# Altium schematic sheet Transmitter.SchDoc
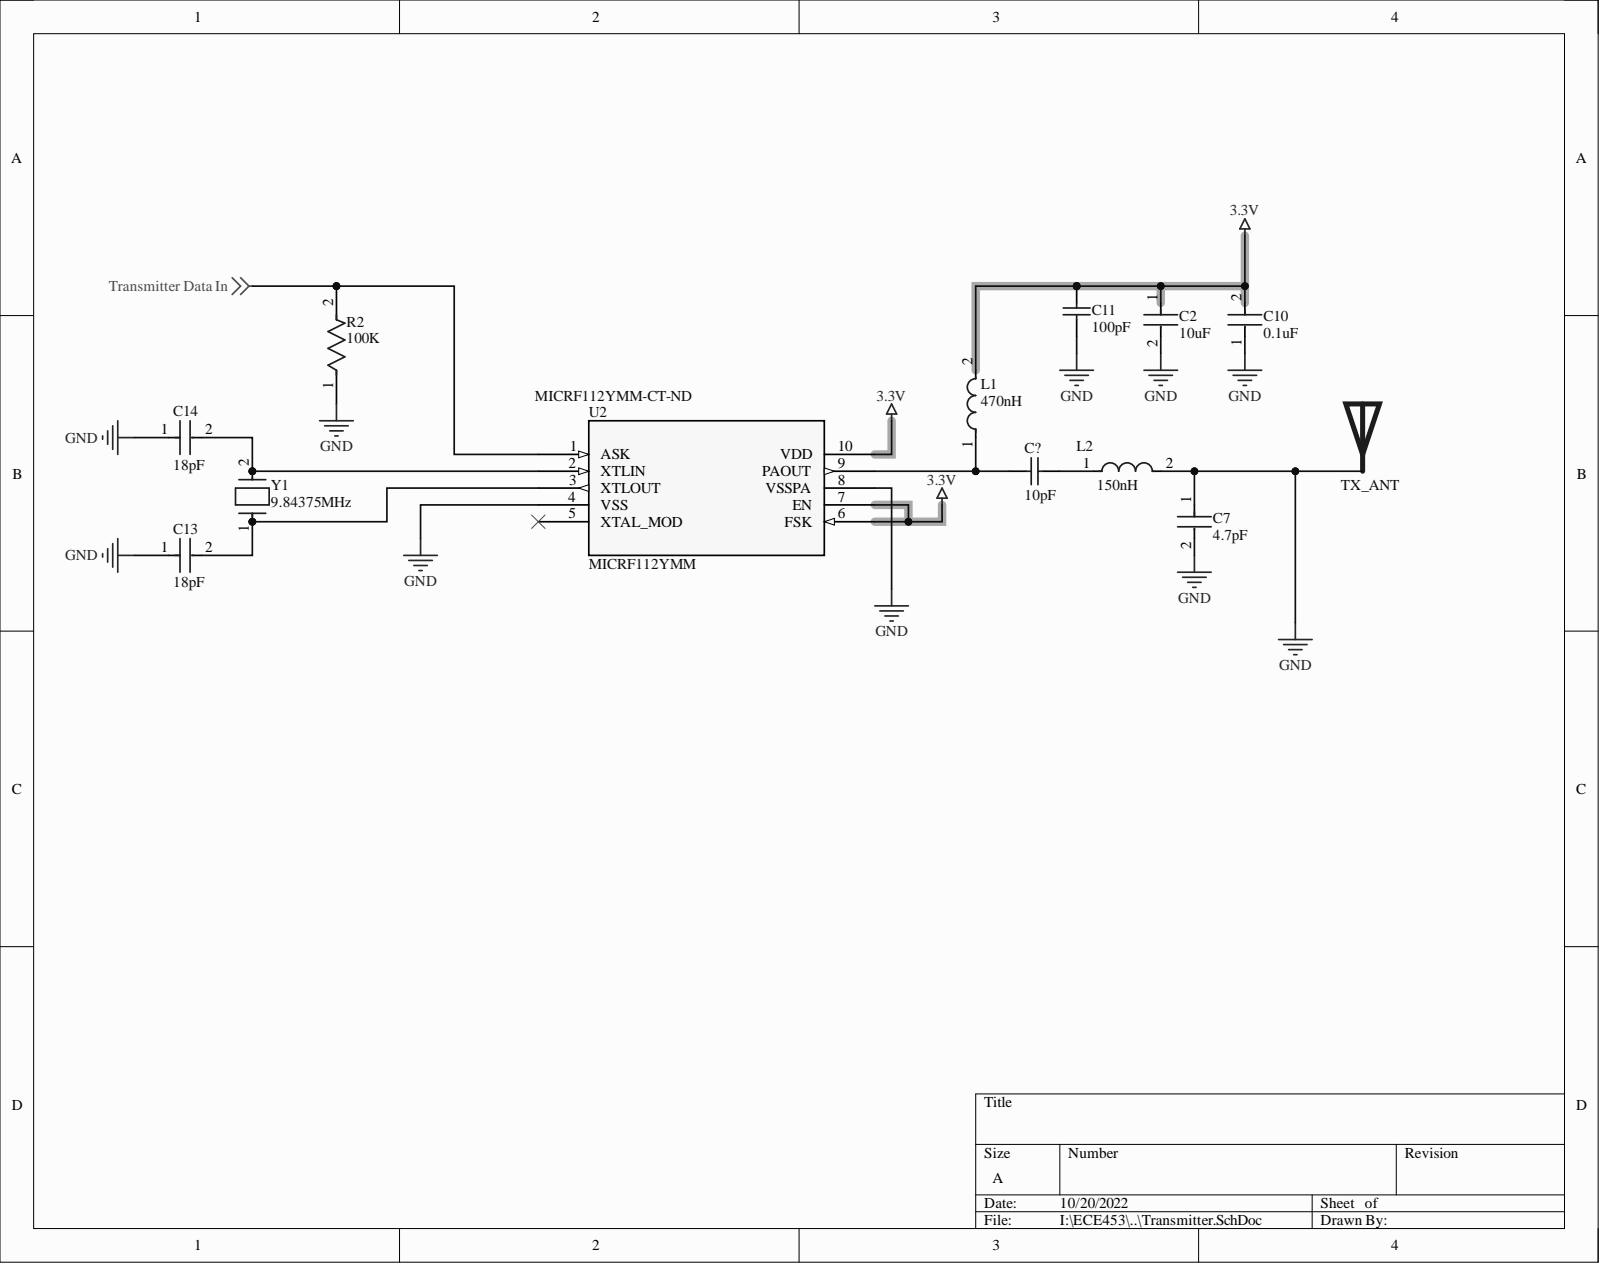
[diagram p0: Transmitter.SchDoc]

## Parts
C2  10uF  [FP-GRM188-0_1-e0_2_0_55-IPC_A]
C7  4.7pF  [FP-C0402-BB-MFG]
C10  0.1uF  [FP-GRM15-MFG]
C11  100pF  [CAPC1608X90X35NL10T15]
C13  18pF  [FP-C0805C-DN-MFG]
C14  18pF  [FP-C0805C-DN-MFG]
C?  10pF  [CAPC1608X90X35LL10T15]
L1  470nH  [FP-LQW2UAS_00-MFG]
L2  150nH  [FP-LQW2BHN_03-MFG]
R2  100K  [FP-ERJ2RK-IPC_C]
TX_ANT  TX Antenna  [TX Antenna]  (value not drawn)
U2  MICRF112YMM  Supplier Part Number 1: MICRF112YMM-CT-ND  [MSOP10_MC_MCH]
Y1  9.84375MHz  [FP-ABM7-MFG]

## Nets
3.3V: C2.1 C10.2 C11.2 L1.2 U2.6(FSK) U2.7(EN) U2.10(VDD)
GND: C2.2 C7.1 C7.2 C10.1 C11.1 C13.1 C14.1 L2.2 R2.1 U2.4(VSS) U2.8(VSSPA)
Transmitter Data In: R2.2 U2.1(ASK)
NetC13_2: C13.2 U2.3(XTLOUT) Y1.1
NetC14_2: C14.2 U2.2(XTLIN) Y1.2
NetC?_1: C?.1 L1.1 U2.9(PAOUT)
NetC?_2: C?.2 L2.1

Not connected: U2 5(XTAL_MOD)

## Library data of the parts
C2 = CMP-2006-02792-2: Chip Multilayer Ceramic Capacitors for General Purpose, 0603, 10uF, X5R, 15%, 20%, 6.3V; Capacitance: 10uF; Case Code (Imperial): 0603; Case Code (Metric): 1608; Case/Package: 0603; Component Kind: Standard; Depth: 0.8mm; Dielectric: X5R; Height: 0.8mm; Lead Free: Lead Free; Length: 1.6mm; Library Name: Altium Content Vault; Material: Ceramic; Max Operating Temperature: 85°C; Min Operating Temperature: -55°C; Mount: Surface Mount; Package Quantity: 4000; Packaging: Tape and Reel; Radiation Hardening: No; REACH SVHC: No SVHC; Termination: SMD/SMT; Thickness: 0.035inch; Tolerance: 20%; Voltage: 6.3V; Voltage Rating: 6.3V; Voltage Rating (DC): 6.3V; Width: 0.031inch
C7 = CMP-2008-03310-2: CAP CER 4.7PF 50V NP0 0402; Manufacturer: Kemet; Manufacturer Part Number: C0402C479C5GACTU; Material: Ceramic; Temperature Characteristic: C0G; Temperature Coefficient: 15%; Tolerance: 0.25 pF; Automotive: No; Capacitance: 4.7 pF; Category: Cap; Component Kind: Standard; ComponentLink2Description: Datasheet; ComponentLink2URL: https://content.kemet.com/datasheets/KEM_C1002_X7R_SMD.pdf; Device Class L1: Passive Components; Device Class L2: Capacitors; Device Class L3: Ceramic Capacitors; Digikey Description: CAP CER 4.7PF 50V NP0 0402; Height: 0.55mm; Ipc Land Pattern Name: CAPC10050X50; Lead Free: Yes; Library Name: Altium Content Vault; Mouser Description: Multilayer Ceramic Capacitors MLCC - SMD/SMT 50volts 4.7pF C0G .25pF; Octopart: https://octopart.com/c0402c479c5gactu-kemet-9144199; Package: C0402-BB; RoHS: TRUE; Temperature: -55°C to +125°C; Temperature Range High: +125°C; Temperature Range Low: -55°C; Value: 4.7 pF; Voltage Rating: 50 V
C10 = CMP-2100-03641-2: CAP CER 0.1UF 16V X7R 0402; Manufacturer: Murata; Manufacturer Part Number: GRM155R71C104KA88D; Capacitance: 0.10μF; Material: Ceramic; Standoff Height: 0mm; Tolerance: 10%; Value: 0.10μF; Voltage Rating: 16Vdc; Category: Cap; Component Kind: Standard; ComponentLink2Description: Datasheet; ComponentLink2URL: https://search.murata.co.jp/Ceramy/image/img/A01X/G101/ENG/GRM155R71H471KA01-01A.pdf; Device Class L1: Passive Components; Device Class L2: Capacitors; Device Class L3: Ceramic Capacitors; Digikey Description: CAP CER 0.1UF 16V X7R 0402; Height: 0.55mm; Ipc Land Pattern Name: CAPC10050X219; Lead Free: yes; Library Name: Altium Content Vault; Mouser Description: 0402 0.1 uF 16V ±10 % Tolerance X7R SMT Multilayer Ceramic Capacitor; Octopart: https://octopart.com/grm155r71c104ka88d-murata-196880; Package: GRM15; RoHS: TRUE; Temperature: -55°C to +125°C; Temperature Characteristic: X7R; Temperature Range High: +125°C; Temperature Range Low: -55°C
C11 = CMP-2006-02595-1: Manufacturer: Murata; Part Number: GRM1885C1H101JA01D; Component Kind: Standard; Library Name: Altium Content Vault
C13 C14 = CMP-2007-00302-2: CAP CER 18PF 50V C0G/NP0 0805; Manufacturer: KEMET; Manufacturer Part Number: C0805C180J5GACTU; Material: Ceramic; Temperature Characteristic: C0G; Temperature Coefficient: 30ppm/°C; Tolerance: 0.05; Automotive: No; Capacitance: 18 pF; Category: Cap; Component Kind: Standard; ComponentLink2Description: Datasheet; ComponentLink2URL: https://content.kemet.com/datasheets/KEM_C1003_C0G_SMD.pdf; Device Class L1: Passive Components; Device Class L2: Capacitors; Device Class L3: Ceramic Capacitors; Digikey Description: CAP CER 18PF 50V C0G/NP0 0805; Height: 0.88mm; Ipc Land Pattern Name: CAPC200125X78; Lead Free: Yes; Library Name: Altium Content Vault; Mouser Description: Cap Ceramic 18pF 50V C0G 5% SMD 0805 125C Paper T/R; Octopart: https://octopart.com/c0805c180j5gactu-kemet-144810; Package: C0805C-DN; RoHS: TRUE; Temperature: -55°C to +125°C; Temperature Range High: +125°C; Temperature Range Low: -55°C; Value: 18 pF; Voltage Rating: 50 V
C? = CMP-2006-02592-1: Manufacturer: Murata; Part Number: GRM1885C1H100JA01D; Component Kind: Standard; Library Name: Altium Content Vault
L1 = CMP-06042-015512-1: Wire Wound RF Inductor 470nH ±5% 470mA 1.19Ω 1008 (2520); Core Material: Air; DC Resistance (DCR): 1.19R; Q Factor: 45; Self Resonant Frequency: 450MHz; Shielding: Unshielded; Test Frequency: 25MHz; Case Code (Imperial): 1008; Case Code (Metric): 2520; Case/Package: 1008; Component Kind: Standard; Current Rating: 470mA; Depth: 2.65mm; Height: 1.83mm; Height - Seated (Max): 0.08inch; Inductance: 470nH; Inductance Tolerance: 5%; Lead Free: Lead Free; Length: 0.103inch; Library Name: Altium Content Vault; Max DC Current: 470mA; Max Operating Temperature: 125°C; Min Operating Temperature: -55°C; Mount: Surface Mount; Package Quantity: 2000; Packaging: Tape and Reel; Radiation Hardening: No; REACH SVHC: No SVHC; Resistor Type: Wirewound; Series: LQW2UA; Termination: SMD/SMT; Tolerance: 5%; Weight: 0.001376oz; Width: 0.096inch
L2 = CMP-06042-003065-1: Wire Wound RF Inductor 150nH ±5% 390mA 0.47Ω 0805 (2015); Core Material: Non-Magnetic; DC Resistance (DCR): 470mR; Q Factor: 30; Self Resonant Frequency: 350MHz; Shielding: Unshielded; Test Frequency: 100MHz; Case Code (Imperial): 0805; Case Code (Metric): 2012; Case/Package: 0805; Component Kind: Standard; Current Rating: 390mA; DC Current: 390mA; Depth: 1.7mm; Height: 1.7mm; Height - Seated (Max): 0.07inch; Inductance: 150nH; Lead Free: Lead Free; Length: 2mm; Library Name: Altium Content Vault; Max DC Current: 390mA; Max Operating Temperature: 85°C; Military Standard: Not; Min Operating Temperature: -40°C; Mount: Surface Mount; Package Quantity: 2000; Packaging: Tape and Reel; Radiation Hardening: No; Resistor Type: Wirewound; Series Resistance: 470mR; Termination: SMD/SMT; Tolerance: 5%; Weight: 0.000317oz; Width: 0.059inch
R2 = CMP-2002-00608-4: RES SMD 100K OHM 1% 1/10W 0402; Max Power Dissipation: 100mW; Resistance: 100kR; Temperature Coefficient: 100ppm/°C; Voltage Rating: 50V; Case Code (Imperial): 0402; Case Code (Metric): 1005; Case/Package: 0402; Component Kind: Standard; Composition: Thick Film; Contact Plating: Tin; Depth: 0.5mm; Features: Automotive AEC-Q200; Height: 0.35mm; Lead Free: Lead Free; Length: 1mm; Library Name: Altium Content Vault; Max Operating Temperature: 155°C; Military Standard: Not; Min Operating Temperature: -55°C; Mount: Surface Mount; Number of Pins: 2; Number of Terminations: 2; Package Quantity: 1; Packaging: Tape and Reel; Power Rating: 100mW; Radiation Hardening: No; REACH SVHC: No SVHC; Termination: SMD/SMT; Tolerance: 1%; Voltage Rating (DC): 50V; Width: 0.5mm
U2 = MICRF112YMM: No Description Available; Mfr_Name: Microchip; Component Kind: Standard; Library Name: MICRF112YMM.SchLib; Manufacturer_Part_Number: MICRF112YMM; RefDes: RefDes; Supplier 1: Digi-Key; Type: DEV; Copyright: Copyright (C) 2022 Ultra Librarian. All rights reserved.
Y1 = CMP-27762-013556-1: Crystal 9.84375MHz ±30ppm 10pF SMD-2 6mm x 3.5mm; ESR (Equivalent Series Resistance): 50R; Frequency Stability: 100ppm; Case/Package: SMD/SMT; Component Kind: Standard; Frequency: 9.84375MHz; Frequency Tolerance: 30ppm; Height: 0.055inch; Length: 0.236inch; Library Name: Altium Content Vault; Load Capacitance: 10pF; Max Operating Temperature: 85°C; Min Operating Temperature: -40°C; Mount: Surface Mount; Packaging: Tape and Reel; Width: 0.138inch
TX_ANT = TX Antenna: Component Kind: Standard; Library Name: team6.SCHLIB
